# Altium schematic sheet Main.SchDoc(Main)
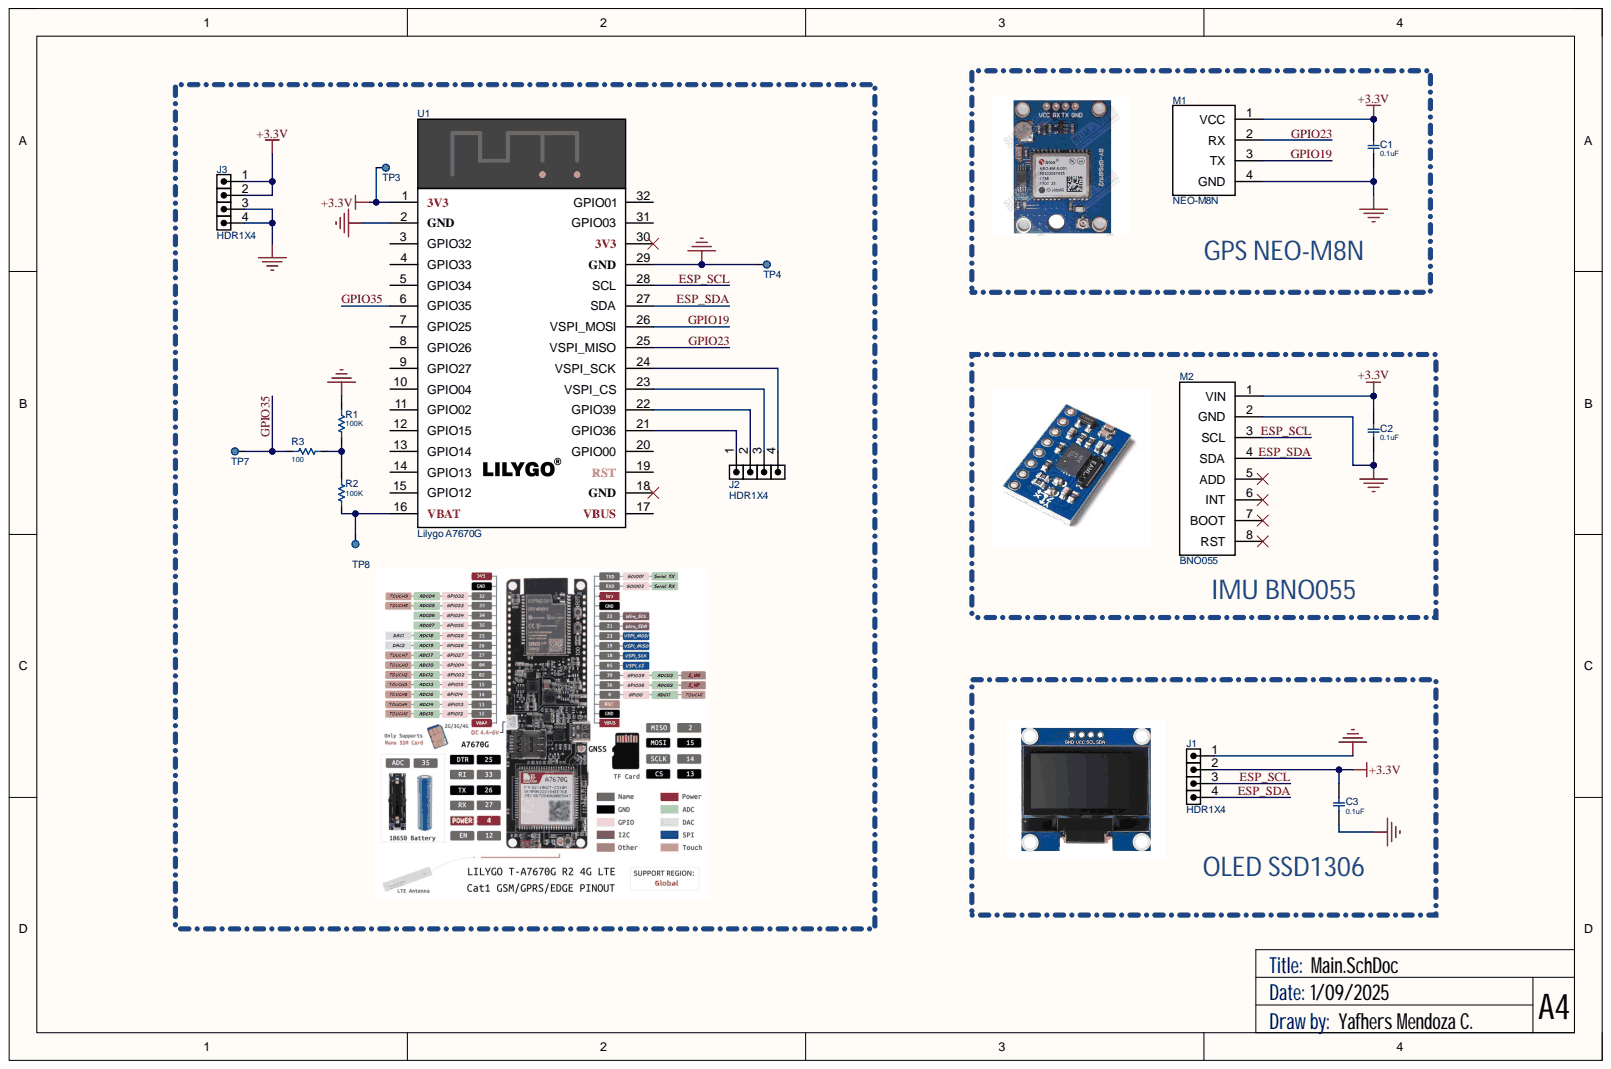
[diagram p0: Main.SchDoc]

## Parts
C1  0.1uF  [C_CER_D5.8]
C2  0.1uF  [C_CER_D5.8]
C3  0.1uF  [C_CER_D5.8]
J1  HDR1X4  [HDRR_M_1X4]
J2  HDR1X4  [HDRR_M_1X4]
J3  HDR1X4  [HDRR_M_1X4]
M1  NEO-M8N  [NEO-M8N]
M2  BNO055  [BNO055]
R1  100K  [RES_0.250W]
R2  100K  [RES_0.250W]
R3  100  [RES_0.250W]
TP3  TestPoint  [TEST_POINT]  (value not drawn)
TP4  TestPoint  [TEST_POINT]  (value not drawn)
TP7  TestPoint  [TEST_POINT]  (value not drawn)
TP8  TestPoint  [TEST_POINT]  (value not drawn)
U1  Lilygo A7670G  [LILYGO-A7670G]

## Nets
+3.3V: C1.1 C2.1 C3.1 J1.2 J3.1 J3.2 M1.1(VCC) M2.1(VIN) TP3.1 U1.1(3V3)
GND: C1.2 C2.2 C3.2 J1.1 J3.3 J3.4 M1.4(GND) M2.2(GND) R1.1 TP4.1 U1.2(GND) U1.29(GND)
ESP_SCL: J1.3 M2.3(SCL) U1.28(SCL)
ESP_SDA: J1.4 M2.4(SDA) U1.27(SDA)
GPIO19: M1.3(TX) U1.26(VSPI_MOSI)
GPIO23: M1.2(RX) U1.25(VSPI_MISO)
GPIO35: R3.1 TP7.1 U1.6(GPIO35)
NetJ2_1: J2.1 U1.21(GPIO36)
NetJ2_2: J2.2 U1.22(GPIO39)
NetJ2_3: J2.3 U1.23(VSPI_CS)
NetJ2_4: J2.4 U1.24(VSPI_SCK)
NetR1_2: R1.2 R2.1 R3.2
NetR2_2: R2.2 TP8.1 U1.16(VBAT)

Not connected: M2 5(ADD) 6(INT) 7(BOOT) 8(RST); U1 3(GPIO32) 4(GPIO33) 5(GPIO34) 7(GPIO25) 8(GPIO26) 9(GPIO27) 10(GPIO04) 11(GPIO02) 12(GPIO15) 13(GPIO14) 14(GPIO13) 15(GPIO12) 17(VBUS) 18(GND) 19(RST) 20(GPIO00) 30(3V3) 31(GPIO03) 32(GPIO01)

## Library data of the parts
C1-C3 = CAP_THD_CERML: Capacitor THD Ceramico; Component Kind: Standard; VALUE: 0.1uF; Library Name: Pasivos.IntLib
J1-J3 = Espadin_M_1x04: CONN, HEADER, Male, pitch: 2.54mm, 4POS; VALUE: HDRM4; Component Kind: Standard; Library Name: Conectores.IntLib
M1 = NEO-M8N: Modulo GPS NEO M8N; Component Kind: Standard; Library Name: Modulos.IntLib
M2 = BNO055: Sensor BNO055; Component Kind: Standard; Library Name: Modulos.IntLib
R1-R3 = RES_THD: Resistor THD; Component Kind: Standard; Library Name: Pasivos.IntLib
  R1 R2: VALUE: 100K
  R3: VALUE: 100
TP3 TP4 TP7 TP8 = TestPoint: Punto de prueba de señales; Component Kind: Standard; Library Name: Conectores.IntLib
U1 = Lilygo-A7670G: Component Kind: Standard; Description: Lilygo A7670G; Library Name: Microcontroladores.IntLib
